# Altium schematic sheet MicroDial_Data_Log_V2_Part2.SchDoc(MicroDial_Data_Log_V2_Part2)
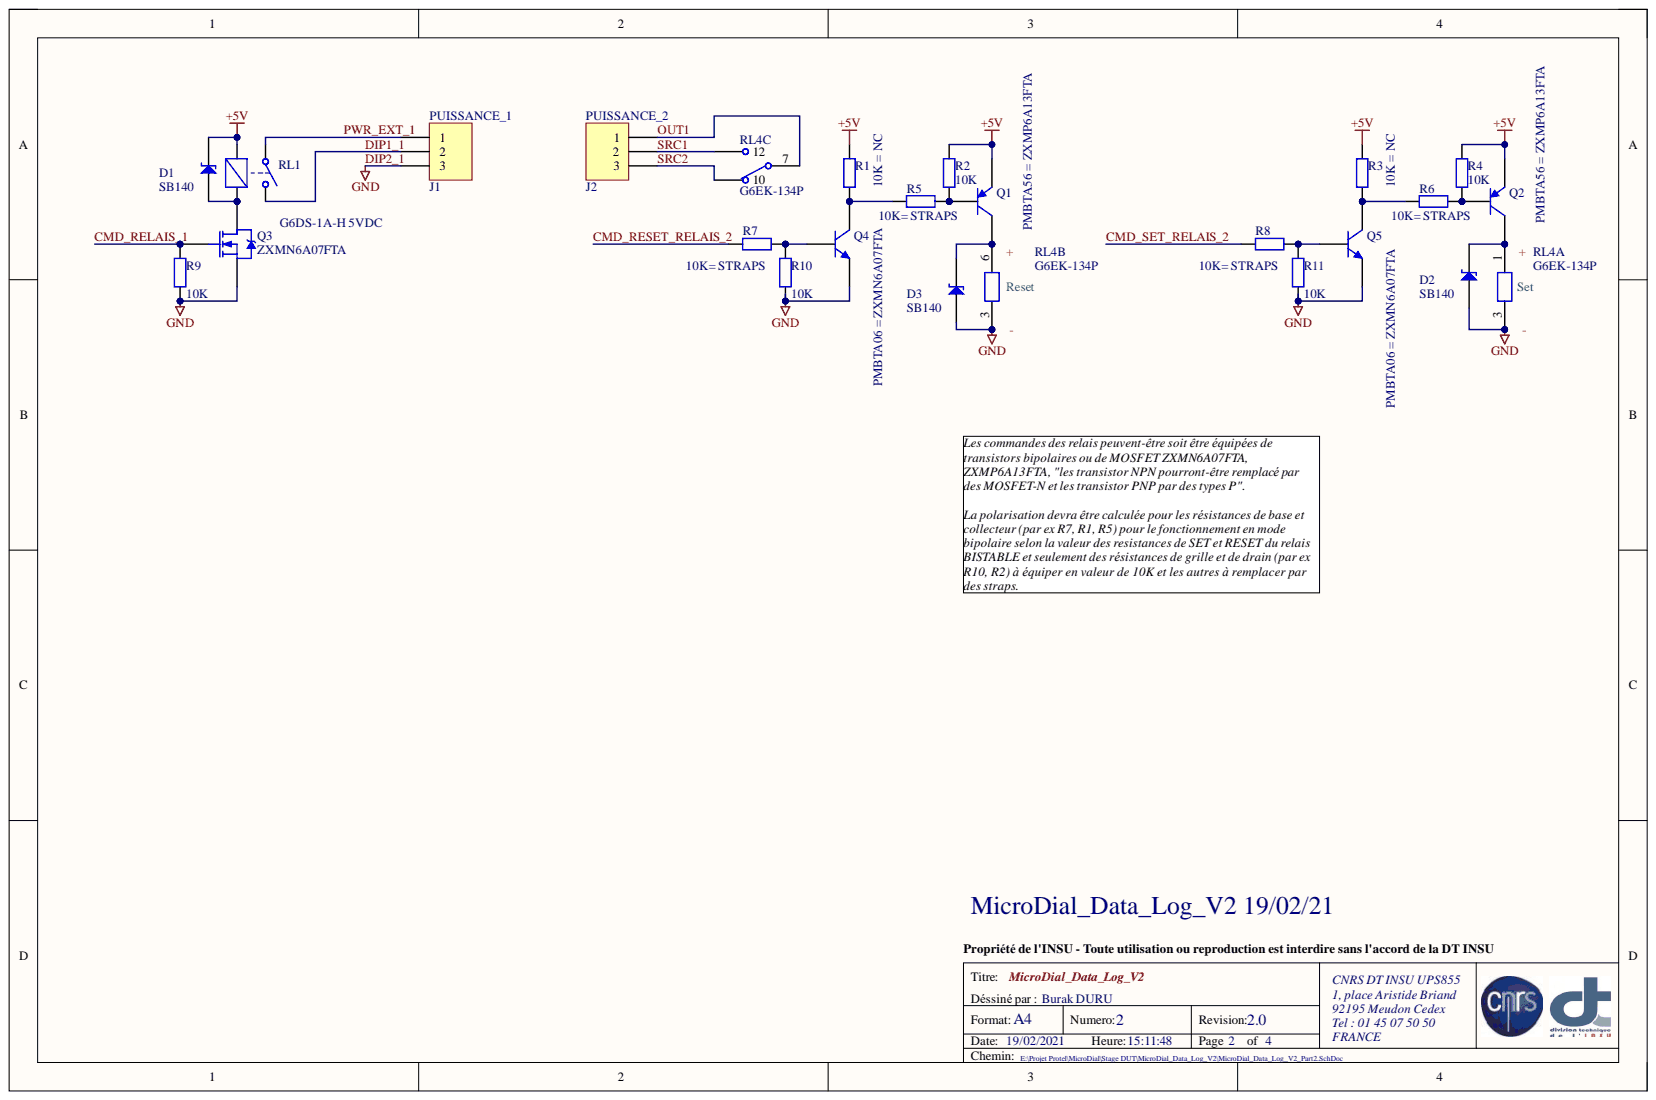
[diagram p0: MicroDial_Data_Log_V2_Part2.SchDoc]

## Parts
D1  SB140  [DO-41]
D2  SB140  [DO-41]
D3  SB140  [DO-41]
J1  PUISSANCE_1  [MKDS 1/3-3.81]
J2  PUISSANCE_2  [MKDS 1/3-3.81]
Q1  PMBTA56 = ZXMP6A13FTA  [SOT-23A]
Q2  PMBTA56 = ZXMP6A13FTA  [SOT-23A]
Q3  ZXMN6A07FTA  [SOT23 - NMOSFET]
Q4  PMBTA06 = ZXMN6A07FTA  [SOT-23A]
Q5  PMBTA06 = ZXMN6A07FTA  [SOT-23A]
R1  value 10K = NC  [R603]  (hidden comment: Res)
R2  value 10K  [R603]  (hidden comment: Res)
R3  value 10K = NC  [R603]  (hidden comment: Res)
R4  value 10K  [R603]  (hidden comment: Res)
R5  value 10K= STRAPS  [R603]  (hidden comment: Res)
R6  value 10K= STRAPS  [R603]  (hidden comment: Res)
R7  value 10K= STRAPS  [R603]  (hidden comment: Res)
R8  value 10K= STRAPS  [R603]  (hidden comment: Res)
R9  value 10K  [R603]  (hidden comment: Res)
R10  value 10K  [R603]  (hidden comment: Res)
R11  value 10K  [R603]  (hidden comment: Res)
RL1  G6DS-1A-H 5VDC  [G6DS-1A-H]
RL4  G6EK-134P  [G6EK-134P]  (parts A,B,C)

## Nets
+5V: D1.2 Q1.2 Q2.2 R1.1 R2.1 R3.1 R4.1 RL1.16
CMD_RELAIS_1: Q3.1 R9.2
CMD_RESET_RELAIS_2: R7.2
CMD_SET_RELAIS_2: R8.2
DIP1_1: J1.2 RL1.6
DIP2_1: D2.1 D3.1 J1.3 Q3.3 Q4.2 Q5.2 R9.1 R10.1 R11.1 RL4.3
OUT1: J2.1 RL4.7
PWR_EXT_1: J1.1 RL1.8
SRC1: J2.2 RL4.12
SRC2: J2.3 RL4.10
NetD1_1: D1.1 Q3.2 RL1.15
NetD2_2: D2.2 Q2.3 RL4.1
NetD3_2: D3.2 Q1.3 RL4.6
NetQ1_1: Q1.1 R2.2 R5.2
NetQ2_1: Q2.1 R4.2 R6.2
NetQ4_1: Q4.1 R7.1 R10.2
NetQ4_3: Q4.3 R1.2 R5.1
NetQ5_1: Q5.1 R8.1 R11.2
NetQ5_3: Q5.3 R3.2 R6.1

## Library data of the parts
D1-D3 = Diode_Schottky: SB140  Redresseur Schottky, 40 V, 1 A, Une, DO-41 (DO-204AL), 2 Broche(s), 500 mV; Code Commande: F146-7528; Component Kind: Standard; Value: SB140; Library Name: pSDLA.IntLib
J1 J2 = PHOENIX CONTACT MKDS 1/3-3.81: PHOENIX CONTACT MKDS 1/3-3.81  SR 3V DROIT; Code Commande: F370-4580; Value: PHOENIX CONTACT MKDS 1/ 3-3,81 - 1727023; Component Kind: Standard; Library Name: pSDLA.IntLib
Q1 Q2 = PMBTA56: PNP General Purpose Amplifier; Code Commande: Mouser 771-PMBTA56-T/R ou F184-3770; Component Kind: Standard; Value: PMBTA56 = ZXMP6A13FTA; Library Name: pSDLA.IntLib
Q3 = ZXMN6A07FTA: 60V N-Channel ENHANCEMENT MODE MOSFET; Code Commande: Mouser 522-ZXMN6A07FTA ou F952-5769; Component Kind: Standard; Simulation: ZXMN6A07F; Value: ZXMN6A07FTA; Library Name: pSDLA.IntLib
Q4 Q5 = PMBTA06: NPN General Purpose Amplifier; Code Commande: MOUSER 771-PMBTA06-T/R  ou F9525769; Component Kind: Standard; Value: PMBTA06  = ZXMN6A07FTA; Library Name: pSDLA.IntLib
R1 R3 = Res: WF06P1002FTL Résistance à puce CMS, 10 kohm, ± 1%, 125 mW, 0603 [1608 Metric], Couche épaisse, Haute puissance; Code Commande: F250-2802 = NC; Component Kind: Standard; Library Name: pSDLA.IntLib
R2 R4 R9-R11 = Res: WF06P1002FTL Résistance à puce CMS, 10 kohm, ± 1%, 125 mW, 0603 [1608 Metric], Couche épaisse, Haute puissance; Code Commande: F250-2802; Component Kind: Standard; Library Name: pSDLA.IntLib
R5-R8 = Res: WF06P1002FTL Résistance à puce CMS, 10 kohm, ± 1%, 125 mW, 0603 [1608 Metric], Couche épaisse, Haute puissance; Code Commande: F250-2802 = STRAPS; Component Kind: Standard; Library Name: pSDLA.IntLib
RL1 = G6DS-1A-H 5VDC: Relais 1RT Normalement Ouvert 5V 5A; Code Commande: RS613-2305; Value: G6D-1A-ASI 5VDC; Component Kind: Standard; Library Name: pSDLA.IntLib
RL4 = G6EK-134P: SPST RELAIS DOUBLE COIL; Code Commande: Mouser 653-G6EK-134P-DC5 / RS 683-9469; Value: G6EK-134P-ST-US-DC5; Component Kind: Standard; Library Name: pSDLA.IntLib
